# Altium schematic sheet Power.SchDoc
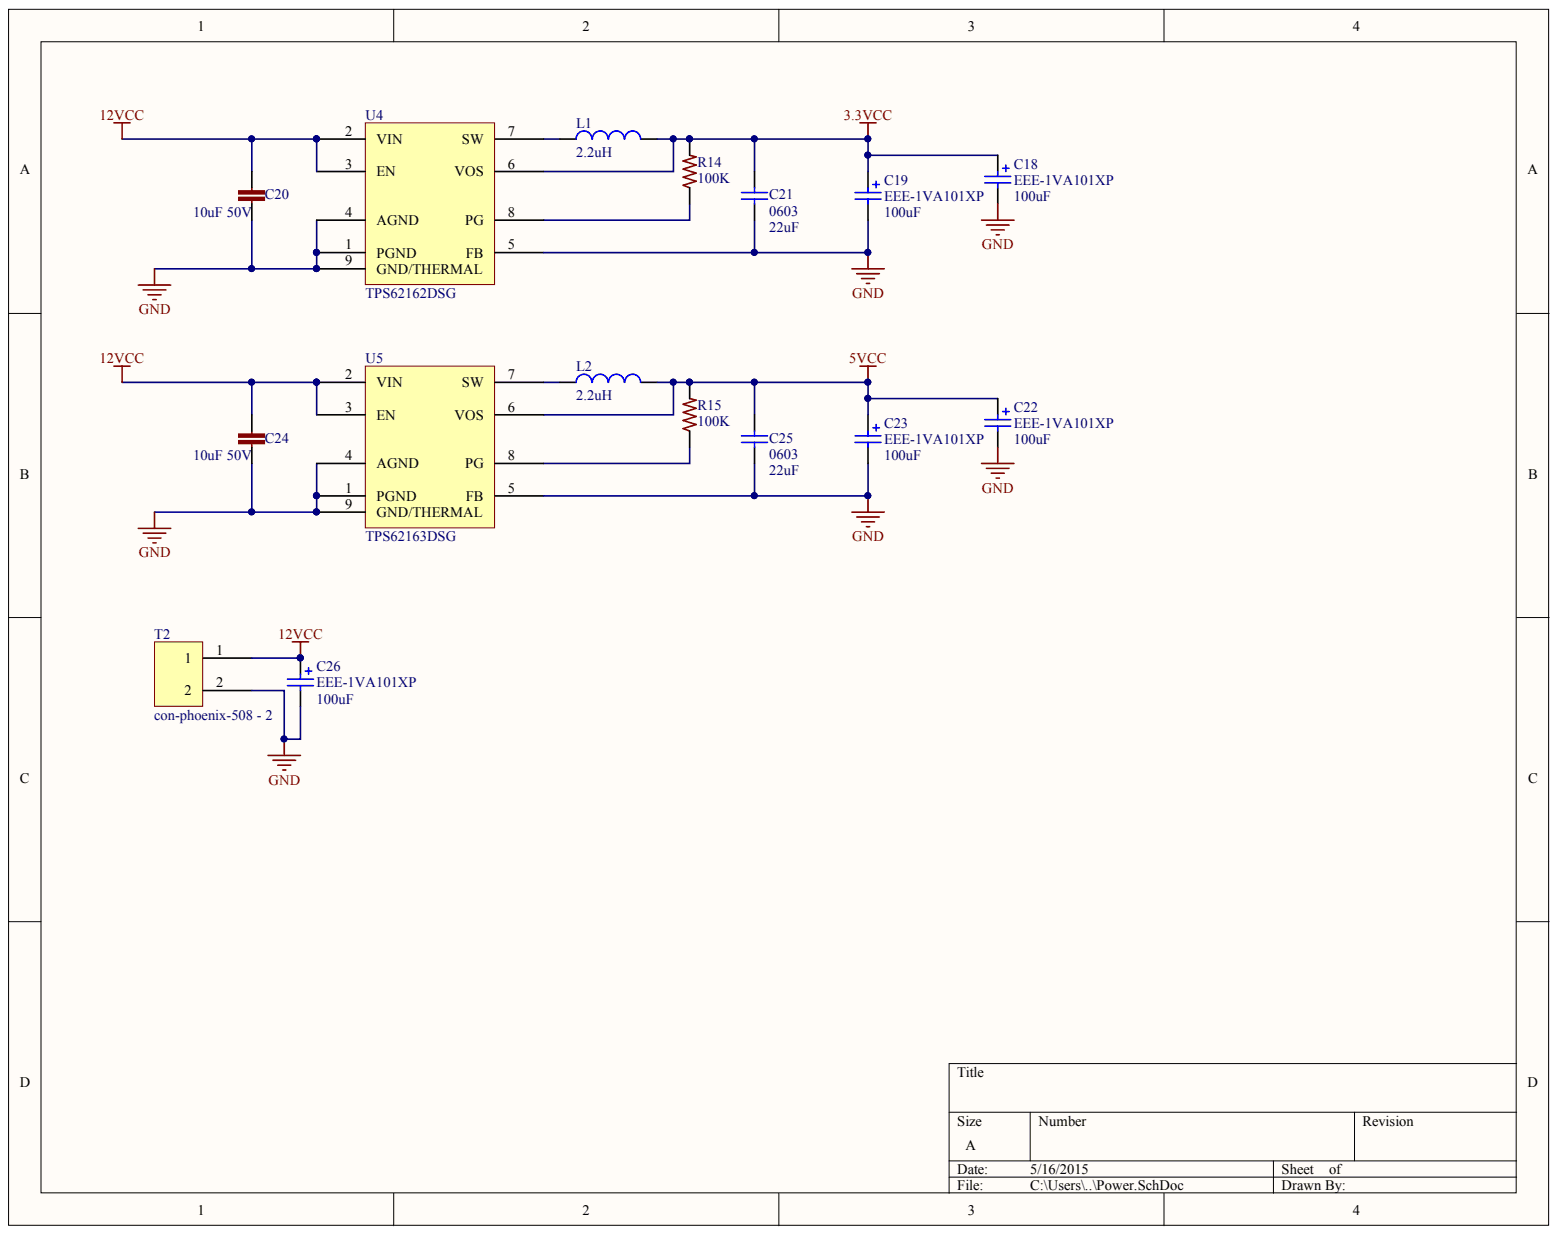
[diagram p0: Power.SchDoc]

## Parts
C18  EEE-1VA101XP  value 100uF  [EEE-1VA101XP]
C19  EEE-1VA101XP  value 100uF  [EEE-1VA101XP]
C20  10uF 50V  [C1206]
C21  0603  value 22uF  [C0805]
C22  EEE-1VA101XP  value 100uF  [EEE-1VA101XP]
C23  EEE-1VA101XP  value 100uF  [EEE-1VA101XP]
C24  10uF 50V  [C1206]
C25  0603  value 22uF  [C0805]
C26  EEE-1VA101XP  value 100uF  [EEE-1VA101XP]
L1  value 2.2uH  [VLS252012T-2R2M1R3]  (hidden comment: VLS252012T-2R2M1R3)
L2  value 2.2uH  [VLS252012T-2R2M1R3]  (hidden comment: VLS252012T-2R2M1R3)
R14  100K  [R0603]
R15  100K  [R0603]
T2  con-phoenix-508 - 2  [MSTBV2 - duplicate]
U4  TPS62162DSG  [DSG8-1600X900TP]
U5  TPS62163DSG  [DSG8-1600X900TP]

## Nets
3.3VCC: C18.1 C19.1 C21.2 L1.2 R14.2 U4.6(VOS)
5VCC: C22.1 C23.1 C25.2 L2.2 R15.2 U5.6(VOS)
12VCC: C20.1 C24.1 C26.1 T2.1 U4.2(VIN) U4.3(EN) U5.2(VIN) U5.3(EN)
GND: C18.2 C19.2 C20.2 C21.1 C22.2 C23.2 C24.2 C25.1 C26.2 T2.2 U4.1(PGND) U4.4(AGND) U4.5(FB) U4.9(GND/THERMAL) U5.1(PGND) U5.4(AGND) U5.5(FB) U5.9(GND/THERMAL)
NetL1_1: L1.1 U4.7(SW)
NetL2_1: L2.1 U5.7(SW)
NetR14_1: R14.1 U4.8(PG)
NetR15_1: R15.1 U5.8(PG)

## Library data of the parts
C18 C19 C22 C23 C26 = EEE-1VA101XP: CAP ALUM 100UF 35V 20% SMD; Manufacture: Panasonic; Component Kind: Standard; Datasheet: http://industrial.panasonic.com/www-cgi/jvcr13pz.cgi?E+PZ+3+ABA0002+EEE1VA101XP+7+WW; Digikey Part #: PCE3951CT-ND; Height: 7.70mm; Library Name: Tigershark3D.SchLib; Manufacture Part #: EEE-1VA101XP; Mount Type: SMT Panasonic VS D Series; Price: $0.63; Signal Integrity: Cap Pol; Simulation: CAP; Status: Active
C20 C24 = CL31A106KBHNNNE: CAP CER 10UF 50V 10% X5R 1206; Manufacturer: Samsung; LastRevisionNote: ImportComponents; Component Kind: Standard; LastRevisionDate: 25-mar-2015; Library Name: Tigershark3D.SchLib; ManufacturerPartNumber: CL31A106KBHNNNE; Published: 25-mar-2015; Signal Integrity: Model Name; Simulation: Model Name; VALUE: 10uF 50V; Publisher: UltiMachine
C21 C25 = CL21A226KQQNNNE: CAP CER 22UF 6.3V 10% X5R 0805; MFR: Samsung; Voltage: 6.3VDC; Component Kind: Standard; Component Type: Chip Capacitor; Datasheet: http://www.digikey.com/product-detail/en/CL21A226KQQNNNE/1276-2907-2-ND/3888565; Digikey #: 1276-2907-2-ND; Height: 1mm; LatestRevisionDate: April 2015; Library Name: Sensor Package.SchLib; MFR Part #: CL21A226KQQNNNE; Mounting Type: SMT 0805; PackageReference: 0805; Part Description: CAP CER 22UF 6.3V 10% X5R 0805; Published: April 2015; Signal Integrity: Cap Semi; Simulation: CAP; Tolerance: 10%
L1 L2 = VLS252012T-2R2M1R3: MFR: TDK Corporation; Component Kind: Standard; Description: VLS252012T-2R2M1R3; LatestRevisionDate: April 2015; LatestRevisionNote: IPC-7351 Footprint Added.; Library Name: Sensor Package.SchLib; MFR#: VLS252012T-2R2M1R3; PackageDescription: Wire Wound Inductor Package; PackageReference: Custom Wound INductor; Published: April 2015; Signal Integrity: Inductor; Publisher: Adam Vadala-Roth
R14 R15 = RC0603FR-07100KL: RES 100K OHM 1/10W 1% 0603 SMD; LastRevisionNote: ImportComponents; Component Kind: Standard; LastRevisionDate: 23-Apr-2014; Library Name: 3Delight.SCHLIB; ManufacturerPartNumber: RC0603FR-07100KL; Published: 23-Apr-2014; Signal Integrity: Model Name; Simulation: Model Name; VALUE: 100K; Publisher: UltiMachine
T2 = con-phoenix-508_2: Component Kind: Standard; Description: con-phoenix-508 - 2; Library Name: ZMBo Schematic Library.SchLib
U4 U5 = TPS62162SOP: Component Kind: Standard; Library Name: Adams Altium Library.SchLib
